# Altium schematic sheet BACKPMAN30_CPU.SchDoc
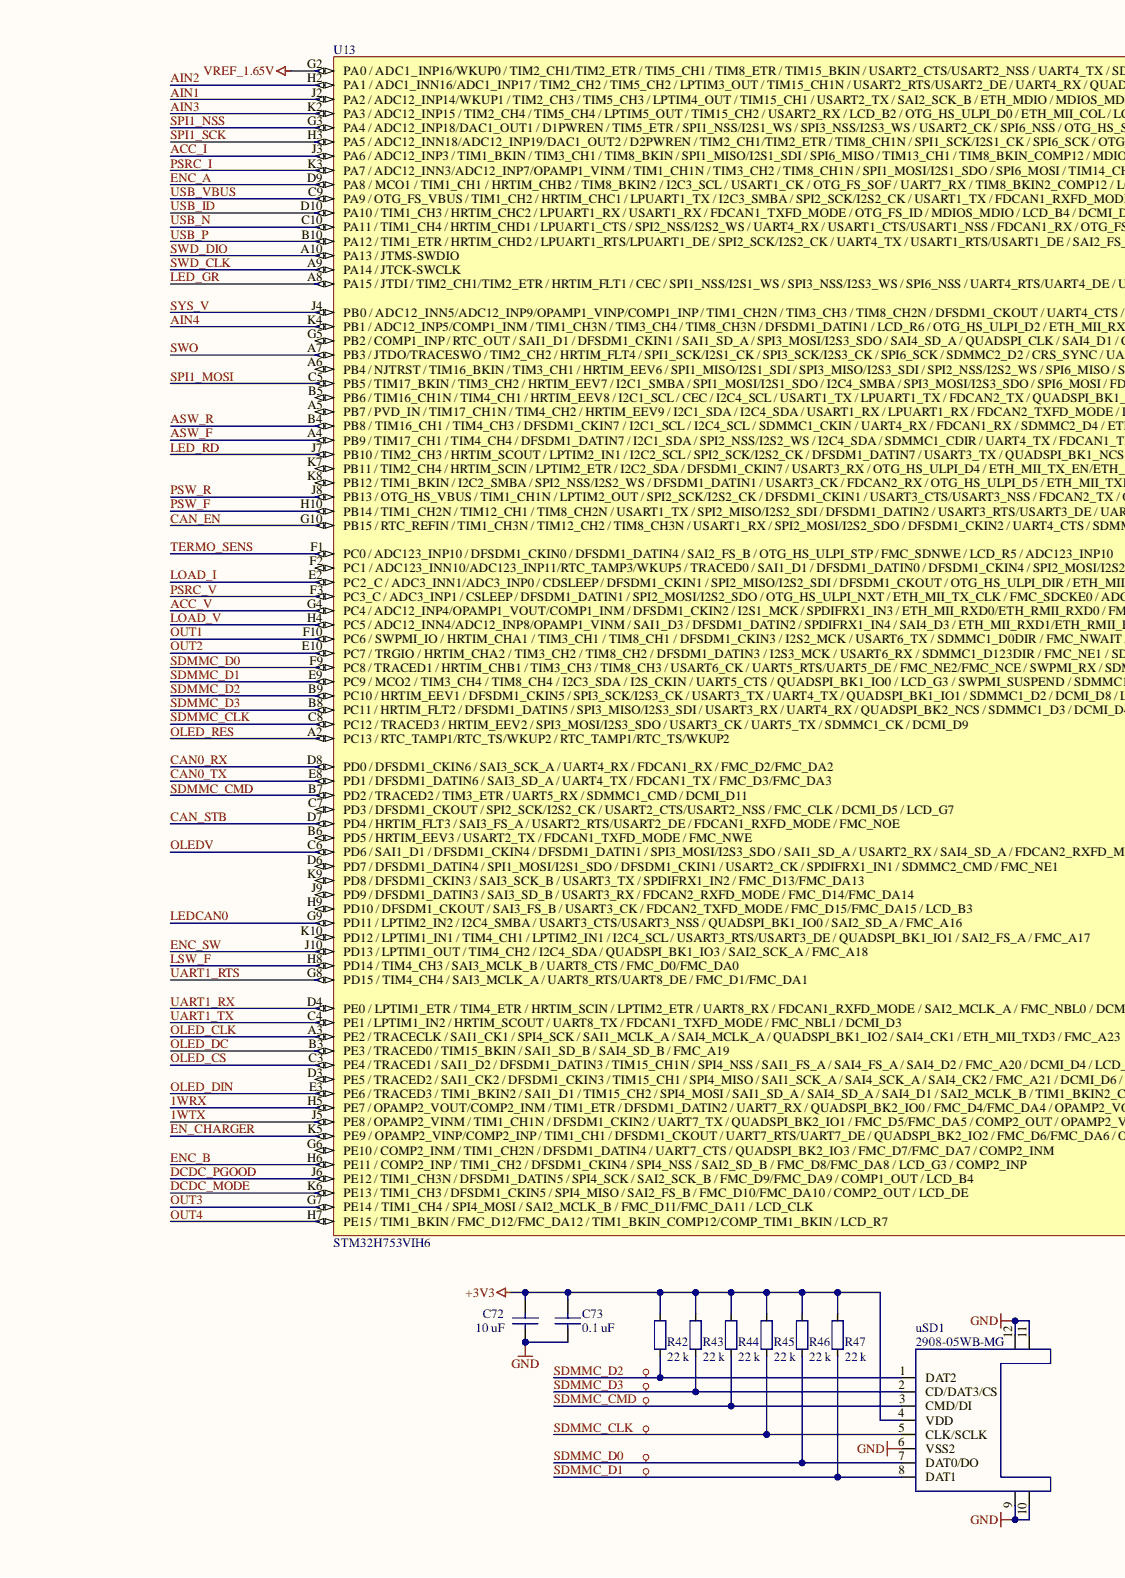
[diagram p0: BACKPMAN30_CPU.SchDoc, left]
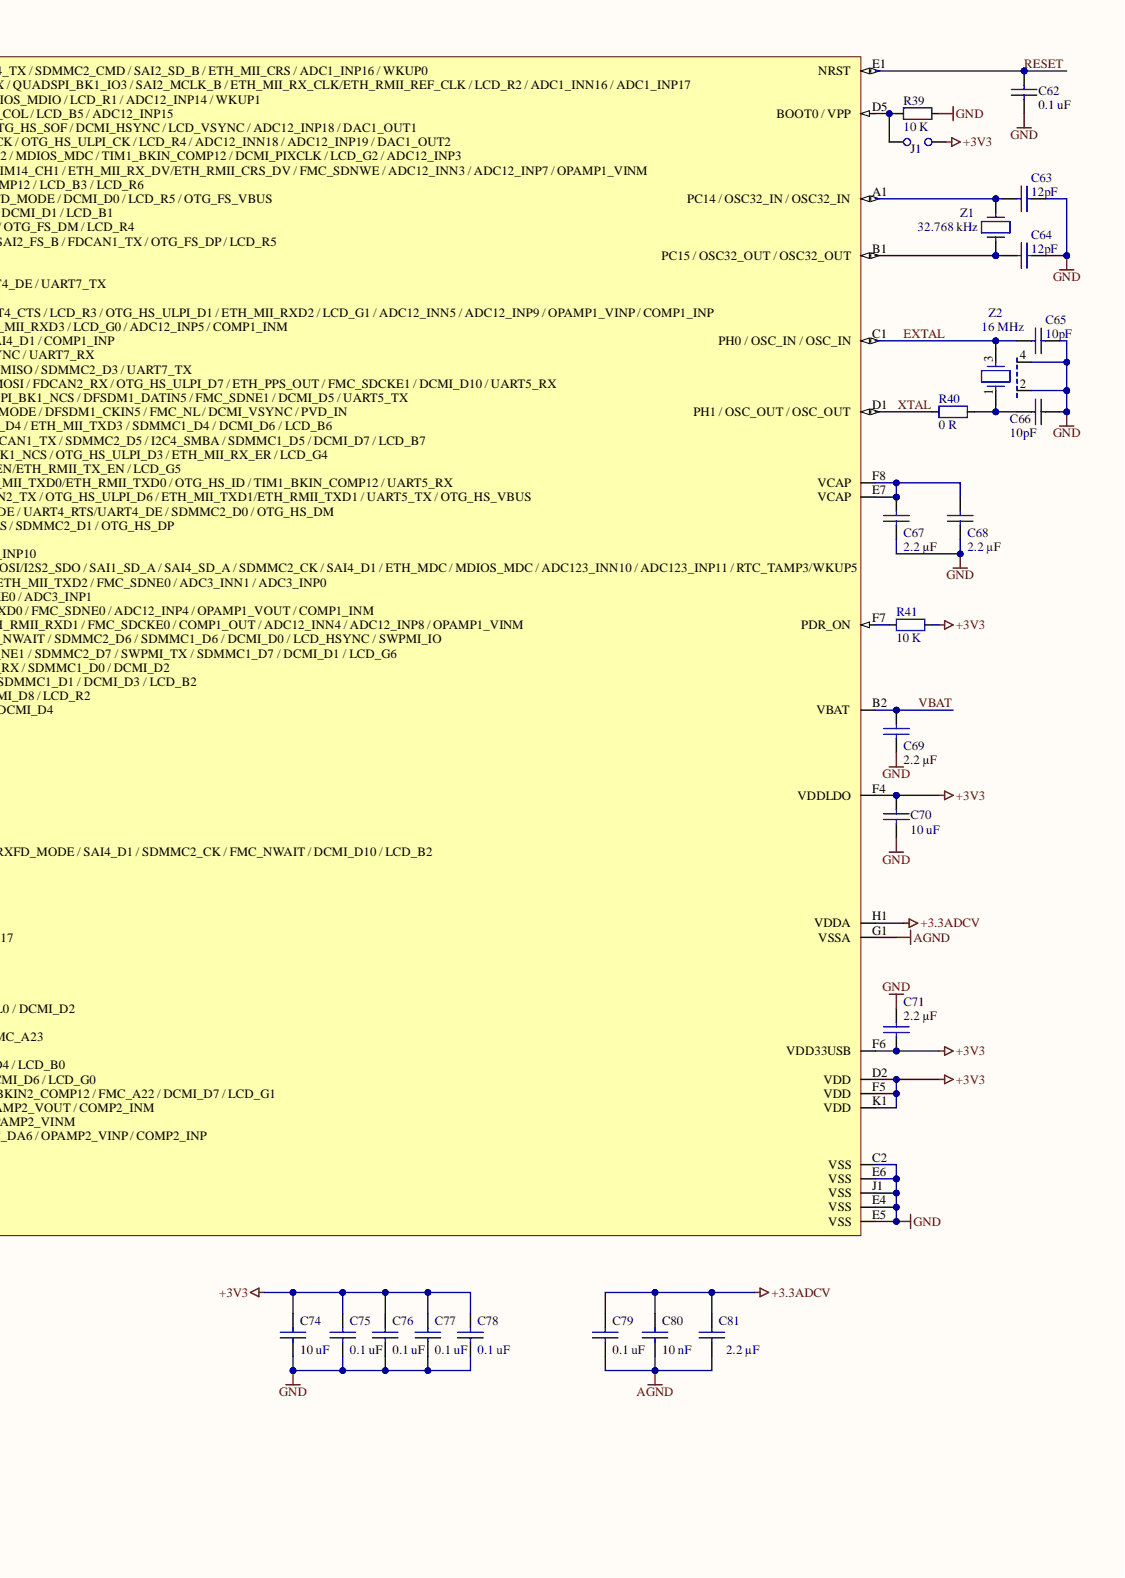
[diagram p1: BACKPMAN30_CPU.SchDoc, right]

## Parts
C62  value 0.1 uF  [1608[0603]]  (hidden comment: CL10B104KA8NNND)
C63  value 12pF  [0402 CAP]  (hidden comment: Capacitor)
C64  value 12pF  [0402 CAP]  (hidden comment: Capacitor)
C65  value 10pF  [0402 CAP]  (hidden comment: Capacitor)
C66  value 10pF  [0402 CAP]  (hidden comment: Capacitor)
C67  value 2.2 µF  [1608[0603]]  (hidden comment: C1608X5R1C225K080AB)
C68  value 2.2 µF  [1608[0603]]  (hidden comment: C1608X5R1C225K080AB)
C69  value 2.2 µF  [1608[0603]]  (hidden comment: C1608X5R1C225K080AB)
C70  value 10 uF  [2012[0805]]  (hidden comment: GRM21BR61E106KA73L)
C71  value 2.2 µF  [1608[0603]]  (hidden comment: C1608X5R1C225K080AB)
C72  value 10 uF  [2012[0805]]  (hidden comment: GRM21BR61E106KA73L)
C73  value 0.1 uF  [1608[0603]]  (hidden comment: 0603B104K500CT)
C74  value 10 uF  [2012[0805]]  (hidden comment: GRM21BR61E106KA73L)
C75  value 0.1 uF  [1608[0603]]  (hidden comment: CL10B104KA8NNND)
C76  value 0.1 uF  [1608[0603]]  (hidden comment: CL10B104KA8NNND)
C77  value 0.1 uF  [1608[0603]]  (hidden comment: CL10B104KA8NNND)
C78  value 0.1 uF  [1608[0603]]  (hidden comment: CL10B104KA8NNND)
C79  value 0.1 uF  [1608[0603]]  (hidden comment: CL10B104KA8NNND)
C80  value 10 nF  [1608[0603]]  (hidden comment: GMC10X7R103M50NT)
C81  value 2.2 µF  [1608[0603]]  (hidden comment: C1608X5R1C225K080AB)
J1  PH1-02-TA-HT  [HDR1X2V]  (value not drawn)
R39  value 10 K  [0603]  (hidden comment: RMCF0603FT10K0)
R40  value 0 R  [0603]  (hidden comment: CR0603-10W-000T)
R41  value 10 K  [0603]  (hidden comment: RMCF0603FT10K0)
R42  value 22 k  [0402]  (hidden comment: Resistor)
R43  value 22 k  [0402]  (hidden comment: Resistor)
R44  value 22 k  [0402]  (hidden comment: Resistor)
R45  value 22 k  [0402]  (hidden comment: Resistor)
R46  value 22 k  [0402]  (hidden comment: Resistor)
R47  value 22 k  [0402]  (hidden comment: Resistor)
U13  STM32H753VIH6  [TFBGA100]
uSD1  2908-05WB-MG  [2908-05WB-MG]
Z1  value 32.768 kHz  [ABS7]  (hidden comment: ABS07-32.768KHZ-T)
Z2  value 16 MHz  [4-SMD 2.00mm x 1.60mm]  (hidden comment: ABM11-16.000MHZ-9-B1U-T)

## Nets
+3.3ADCV: C79.2 C80.1 C81.1 U13.H1(VDDA)
+3V3: C70.2 C71.2 C72.2 C73.2 C74.2 C75.2 C76.2 C77.2 C78.2 J1.2 R41.1 R42.2 R43.2 R44.2 R45.2 R46.2 R47.2 U13.D2(VDD) U13.F4(VDDLDO) U13.F5(VDD) U13.F6(VDD33USB) U13.K1(VDD) uSD1.4(VDD)
AGND: C79.1 C80.2 C81.2 U13.G1(VSSA)
GND: C62.2 C63.2 C64.2 C65.2 C66.2 C67.2 C68.2 C69.2 C70.1 C71.1 C72.1 C73.1 C74.1 C75.1 C76.1 C77.1 C78.1 R39.1 U13.C2(VSS) U13.E4(VSS) U13.E5(VSS) U13.E6(VSS) U13.J1(VSS) uSD1.6(VSS2) uSD1.9 uSD1.10 uSD1.11 uSD1.12 Z2.2 Z2.4
VREF_1.65V: U13.G2
1WRX: U13.H5
1WTX: U13.J5(PE8_/_OPAMP2_VINM_/_TIM1_CH1N_/_DFSDM1_CKIN2_/_UART7_TX_/_QUADSPI_BK2_IO1_/_FMC_D5/FMC_DA5_/_COMP2_OUT_/_OPAMP2_VINM)
ACC_I: U13.J3(PA6_/_ADC12_INP3_/_TIM1_BKIN_/_TIM3_CH1_/_TIM8_BKIN_/_SPI1_MISO/I2S1_SDI_/_SPI6_MISO_/_TIM13_CH1_/_TIM8_BKIN_COMP12_/_MDIOS_MDC_/_TIM1_BKIN_COMP12_/_DCMI_PIXCLK_/_LCD_G2_/_ADC12_INP3)
ACC_V: U13.G4(PC4_/_ADC12_INP4/OPAMP1_VOUT/COMP1_INM_/_DFSDM1_CKIN2_/_I2S1_MCK_/_SPDIFRX1_IN3_/_ETH_MII_RXD0/ETH_RMII_RXD0_/_FMC_SDNE0_/_ADC12_INP4_/_OPAMP1_VOUT_/_COMP1_INM)
AIN1: U13.J2(PA2_/_ADC12_INP14/WKUP1_/_TIM2_CH3_/_TIM5_CH3_/_LPTIM4_OUT_/_TIM15_CH1_/_USART2_TX_/_SAI2_SCK_B_/_ETH_MDIO_/_MDIOS_MDIO_/_LCD_R1_/_ADC12_INP14_/_WKUP1)
AIN2: U13.H2(PA1_/_ADC1_INN16/ADC1_INP17_/_TIM2_CH2_/_TIM5_CH2_/_LPTIM3_OUT_/_TIM15_CH1N_/_USART2_RTS/USART2_DE_/_UART4_RX_/_QUADSPI_BK1_IO3_/_SAI2_MCLK_B_/_ETH_MII_RX_CLK/ETH_RMII_REF_CLK_/_LCD_R2_/_ADC1_INN16_/_ADC1_INP17)
AIN3: U13.K2
AIN4: U13.K4(PB1_/_ADC12_INP5/COMP1_INM_/_TIM1_CH3N_/_TIM3_CH4_/_TIM8_CH3N_/_DFSDM1_DATIN1_/_LCD_R6_/_OTG_HS_ULPI_D2_/_ETH_MII_RXD3_/_LCD_G0_/_ADC12_INP5_/_COMP1_INM)
ASW_F: U13.A4(PB9_/_TIM17_CH1_/_TIM4_CH4_/_DFSDM1_DATIN7_/_I2C1_SDA_/_SPI2_NSS/I2S2_WS_/_I2C4_SDA_/_SDMMC1_CDIR_/_UART4_TX_/_FDCAN1_TX_/_SDMMC2_D5_/_I2C4_SMBA_/_SDMMC1_D5_/_DCMI_D7_/_LCD_B7)
ASW_R: U13.B4(PB8_/_TIM16_CH1_/_TIM4_CH3_/_DFSDM1_CKIN7_/_I2C1_SCL_/_I2C4_SCL_/_SDMMC1_CKIN_/_UART4_RX_/_FDCAN1_RX_/_SDMMC2_D4_/_ETH_MII_TXD3_/_SDMMC1_D4_/_DCMI_D6_/_LCD_B6)
CAN0_RX: U13.D8(PD0_/_DFSDM1_CKIN6_/_SAI3_SCK_A_/_UART4_RX_/_FDCAN1_RX_/_FMC_D2/FMC_DA2)
CAN0_TX: U13.E8(PD1_/_DFSDM1_DATIN6_/_SAI3_SD_A_/_UART4_TX_/_FDCAN1_TX_/_FMC_D3/FMC_DA3)
CAN_EN: U13.G10(PB15_/_RTC_REFIN_/_TIM1_CH3N_/_TIM12_CH2_/_TIM8_CH3N_/_USART1_RX_/_SPI2_MOSI/I2S2_SDO_/_DFSDM1_CKIN2_/_UART4_CTS_/_SDMMC2_D1_/_OTG_HS_DP)
CAN_STB: U13.D7(PD4_/_HRTIM_FLT3_/_SAI3_FS_A_/_USART2_RTS/USART2_DE_/_FDCAN1_RXFD_MODE_/_FMC_NOE)
DCDC_MODE: U13.K6(PE13_/_TIM1_CH3_/_DFSDM1_CKIN5_/_SPI4_MISO_/_SAI2_FS_B_/_FMC_D10/FMC_DA10_/_COMP2_OUT_/_LCD_DE)
DCDC_PGOOD: U13.J6(PE12_/_TIM1_CH3N_/_DFSDM1_DATIN5_/_SPI4_SCK_/_SAI2_SCK_B_/_FMC_D9/FMC_DA9_/_COMP1_OUT_/_LCD_B4)
EN_CHARGER: U13.K5(PE9_/_OPAMP2_VINP/COMP2_INP_/_TIM1_CH1_/_DFSDM1_CKOUT_/_UART7_RTS/UART7_DE_/_QUADSPI_BK2_IO2_/_FMC_D6/FMC_DA6_/_OPAMP2_VINP_/_COMP2_INP)
ENC_A: U13.D9(PA8_/_MCO1_/_TIM1_CH1_/_HRTIM_CHB2_/_TIM8_BKIN2_/_I2C3_SCL_/_USART1_CK_/_OTG_FS_SOF_/_UART7_RX_/_TIM8_BKIN2_COMP12_/_LCD_B3_/_LCD_R6)
ENC_B: U13.H6(PE11_/_COMP2_INP_/_TIM1_CH2_/_DFSDM1_CKIN4_/_SPI4_NSS_/_SAI2_SD_B_/_FMC_D8/FMC_DA8_/_LCD_G3_/_COMP2_INP)
ENC_SW: U13.J10(PD13_/_LPTIM1_OUT_/_TIM4_CH2_/_I2C4_SDA_/_QUADSPI_BK1_IO3_/_SAI2_SCK_A_/_FMC_A18)
EXTAL: C65.1 U13.C1(PH0_/_OSC_IN_/_OSC_IN) Z2.3
LED_GR: U13.A8(PA15_/_JTDI_/_TIM2_CH1/TIM2_ETR_/_HRTIM_FLT1_/_CEC_/_SPI1_NSS/I2S1_WS_/_SPI3_NSS/I2S3_WS_/_SPI6_NSS_/_UART4_RTS/UART4_DE_/_UART7_TX)
LED_RD: U13.J7(PB10_/_TIM2_CH3_/_HRTIM_SCOUT_/_LPTIM2_IN1_/_I2C2_SCL_/_SPI2_SCK/I2S2_CK_/_DFSDM1_DATIN7_/_USART3_TX_/_QUADSPI_BK1_NCS_/_OTG_HS_ULPI_D3_/_ETH_MII_RX_ER_/_LCD_G4)
LEDCAN0: U13.G9(PD11_/_LPTIM2_IN2_/_I2C4_SMBA_/_USART3_CTS/USART3_NSS_/_QUADSPI_BK1_IO0_/_SAI2_SD_A_/_FMC_A16)
LOAD_I: U13.E2(PC2_C_/_ADC3_INN1/ADC3_INP0_/_CDSLEEP_/_DFSDM1_CKIN1_/_SPI2_MISO/I2S2_SDI_/_DFSDM1_CKOUT_/_OTG_HS_ULPI_DIR_/_ETH_MII_TXD2_/_FMC_SDNE0_/_ADC3_INN1_/_ADC3_INP0)
LOAD_V: U13.H4
LSW_F: U13.H8(PD14_/_TIM4_CH3_/_SAI3_MCLK_B_/_UART8_CTS_/_FMC_D0/FMC_DA0)
OLED_CLK: U13.A3(PE2_/_TRACECLK_/_SAI1_CK1_/_SPI4_SCK_/_SAI1_MCLK_A_/_SAI4_MCLK_A_/_QUADSPI_BK1_IO2_/_SAI4_CK1_/_ETH_MII_TXD3_/_FMC_A23)
OLED_CS: U13.C3(PE4_/_TRACED1_/_SAI1_D2_/_DFSDM1_DATIN3_/_TIM15_CH1N_/_SPI4_NSS_/_SAI1_FS_A_/_SAI4_FS_A_/_SAI4_D2_/_FMC_A20_/_DCMI_D4_/_LCD_B0)
OLED_DC: U13.B3(PE3_/_TRACED0_/_TIM15_BKIN_/_SAI1_SD_B_/_SAI4_SD_B_/_FMC_A19)
OLED_DIN: U13.E3
OLED_RES: U13.A2(PC13_/_RTC_TAMP1/RTC_TS/WKUP2_/_RTC_TAMP1/RTC_TS/WKUP2)
OLEDV: U13.C6(PD6_/_SAI1_D1_/_DFSDM1_CKIN4_/_DFSDM1_DATIN1_/_SPI3_MOSI/I2S3_SDO_/_SAI1_SD_A_/_USART2_RX_/_SAI4_SD_A_/_FDCAN2_RXFD_MODE_/_SAI4_D1_/_SDMMC2_CK_/_FMC_NWAIT_/_DCMI_D10_/_LCD_B2)
OUT1: U13.F10(PC6_/_SWPMI_IO_/_HRTIM_CHA1_/_TIM3_CH1_/_TIM8_CH1_/_DFSDM1_CKIN3_/_I2S2_MCK_/_USART6_TX_/_SDMMC1_D0DIR_/_FMC_NWAIT_/_SDMMC2_D6_/_SDMMC1_D6_/_DCMI_D0_/_LCD_HSYNC_/_SWPMI_IO)
OUT2: U13.E10(PC7_/_TRGIO_/_HRTIM_CHA2_/_TIM3_CH2_/_TIM8_CH2_/_DFSDM1_DATIN3_/_I2S3_MCK_/_USART6_RX_/_SDMMC1_D123DIR_/_FMC_NE1_/_SDMMC2_D7_/_SWPMI_TX_/_SDMMC1_D7_/_DCMI_D1_/_LCD_G6)
OUT3: U13.G7(PE14_/_TIM1_CH4_/_SPI4_MOSI_/_SAI2_MCLK_B_/_FMC_D11/FMC_DA11_/_LCD_CLK)
OUT4: U13.H7(PE15_/_TIM1_BKIN_/_FMC_D12/FMC_DA12_/_TIM1_BKIN_COMP12/COMP_TIM1_BKIN_/_LCD_R7)
PSRC_I: U13.K3(PA7_/_ADC12_INN3/ADC12_INP7/OPAMP1_VINM_/_TIM1_CH1N_/_TIM3_CH2_/_TIM8_CH1N_/_SPI1_MOSI/I2S1_SDO_/_SPI6_MOSI_/_TIM14_CH1_/_ETH_MII_RX_DV/ETH_RMII_CRS_DV_/_FMC_SDNWE_/_ADC12_INN3_/_ADC12_INP7_/_OPAMP1_VINM)
PSRC_V: U13.F3(PC3_C_/_ADC3_INP1_/_CSLEEP_/_DFSDM1_DATIN1_/_SPI2_MOSI/I2S2_SDO_/_OTG_HS_ULPI_NXT_/_ETH_MII_TX_CLK_/_FMC_SDCKE0_/_ADC3_INP1)
PSW_F: U13.H10(PB14_/_TIM1_CH2N_/_TIM12_CH1_/_TIM8_CH2N_/_USART1_TX_/_SPI2_MISO/I2S2_SDI_/_DFSDM1_DATIN2_/_USART3_RTS/USART3_DE_/_UART4_RTS/UART4_DE_/_SDMMC2_D0_/_OTG_HS_DM)
PSW_R: U13.J8
RESET: C62.1 U13.E1(NRST)
SDMMC_CLK: R45.1 U13.C8(PC12_/_TRACED3_/_HRTIM_EEV2_/_SPI3_MOSI/I2S3_SDO_/_USART3_CK_/_UART5_TX_/_SDMMC1_CK_/_DCMI_D9) uSD1.5(CLK/SCLK)
SDMMC_CMD: R44.1 U13.B7(PD2_/_TRACED2_/_TIM3_ETR_/_UART5_RX_/_SDMMC1_CMD_/_DCMI_D11) uSD1.3(CMD/DI)
SDMMC_D0: R46.1 U13.F9(PC8_/_TRACED1_/_HRTIM_CHB1_/_TIM3_CH3_/_TIM8_CH3_/_USART6_CK_/_UART5_RTS/UART5_DE_/_FMC_NE2/FMC_NCE_/_SWPMI_RX_/_SDMMC1_D0_/_DCMI_D2) uSD1.7(DAT0/DO)
SDMMC_D1: R47.1 U13.E9(PC9_/_MCO2_/_TIM3_CH4_/_TIM8_CH4_/_I2C3_SDA_/_I2S_CKIN_/_UART5_CTS_/_QUADSPI_BK1_IO0_/_LCD_G3_/_SWPMI_SUSPEND_/_SDMMC1_D1_/_DCMI_D3_/_LCD_B2) uSD1.8(DAT1)
SDMMC_D2: R42.1 U13.B9(PC10_/_HRTIM_EEV1_/_DFSDM1_CKIN5_/_SPI3_SCK/I2S3_CK_/_USART3_TX_/_UART4_TX_/_QUADSPI_BK1_IO1_/_SDMMC1_D2_/_DCMI_D8_/_LCD_R2) uSD1.1(DAT2)
SDMMC_D3: R43.1 U13.B8(PC11_/_HRTIM_FLT2_/_DFSDM1_DATIN5_/_SPI3_MISO/I2S3_SDI_/_USART3_RX_/_UART4_RX_/_QUADSPI_BK2_NCS_/_SDMMC1_D3_/_DCMI_D4) uSD1.2(CD/DAT3/CS)
SPI1_MOSI: U13.C5(PB5_/_TIM17_BKIN_/_TIM3_CH2_/_HRTIM_EEV7_/_I2C1_SMBA_/_SPI1_MOSI/I2S1_SDO_/_I2C4_SMBA_/_SPI3_MOSI/I2S3_SDO_/_SPI6_MOSI_/_FDCAN2_RX_/_OTG_HS_ULPI_D7_/_ETH_PPS_OUT_/_FMC_SDCKE1_/_DCMI_D10_/_UART5_RX)
SPI1_NSS: U13.G3(PA4_/_ADC12_INP18/DAC1_OUT1_/_D1PWREN_/_TIM5_ETR_/_SPI1_NSS/I2S1_WS_/_SPI3_NSS/I2S3_WS_/_USART2_CK_/_SPI6_NSS_/_OTG_HS_SOF_/_DCMI_HSYNC_/_LCD_VSYNC_/_ADC12_INP18_/_DAC1_OUT1)
SPI1_SCK: U13.H3(PA5_/_ADC12_INN18/ADC12_INP19/DAC1_OUT2_/_D2PWREN_/_TIM2_CH1/TIM2_ETR_/_TIM8_CH1N_/_SPI1_SCK/I2S1_CK_/_SPI6_SCK_/_OTG_HS_ULPI_CK_/_LCD_R4_/_ADC12_INN18_/_ADC12_INP19_/_DAC1_OUT2)
SWD_CLK: U13.A9(PA14_/_JTCK-SWCLK)
SWD_DIO: U13.A10(PA13_/_JTMS-SWDIO)
SWO: U13.A7(PB3_/_JTDO/TRACESWO_/_TIM2_CH2_/_HRTIM_FLT4_/_SPI1_SCK/I2S1_CK_/_SPI3_SCK/I2S3_CK_/_SPI6_SCK_/_SDMMC2_D2_/_CRS_SYNC_/_UART7_RX)
SYS_V: U13.J4(PB0_/_ADC12_INN5/ADC12_INP9/OPAMP1_VINP/COMP1_INP_/_TIM1_CH2N_/_TIM3_CH3_/_TIM8_CH2N_/_DFSDM1_CKOUT_/_UART4_CTS_/_LCD_R3_/_OTG_HS_ULPI_D1_/_ETH_MII_RXD2_/_LCD_G1_/_ADC12_INN5_/_ADC12_INP9_/_OPAMP1_VINP_/_COMP1_INP)
TERMO_SENS: U13.F1(PC0_/_ADC123_INP10_/_DFSDM1_CKIN0_/_DFSDM1_DATIN4_/_SAI2_FS_B_/_OTG_HS_ULPI_STP_/_FMC_SDNWE_/_LCD_R5_/_ADC123_INP10)
UART1_RTS: U13.G8(PD15_/_TIM4_CH4_/_SAI3_MCLK_A_/_UART8_RTS/UART8_DE_/_FMC_D1/FMC_DA1)
UART1_RX: U13.D4(PE0_/_LPTIM1_ETR_/_TIM4_ETR_/_HRTIM_SCIN_/_LPTIM2_ETR_/_UART8_RX_/_FDCAN1_RXFD_MODE_/_SAI2_MCLK_A_/_FMC_NBL0_/_DCMI_D2)
UART1_TX: U13.C4(PE1_/_LPTIM1_IN2_/_HRTIM_SCOUT_/_UART8_TX_/_FDCAN1_TXFD_MODE_/_FMC_NBL1_/_DCMI_D3)
USB_ID: U13.D10(PA10_/_TIM1_CH3_/_HRTIM_CHC2_/_LPUART1_RX_/_USART1_RX_/_FDCAN1_TXFD_MODE_/_OTG_FS_ID_/_MDIOS_MDIO_/_LCD_B4_/_DCMI_D1_/_LCD_B1)
USB_N: U13.C10(PA11_/_TIM1_CH4_/_HRTIM_CHD1_/_LPUART1_CTS_/_SPI2_NSS/I2S2_WS_/_UART4_RX_/_USART1_CTS/USART1_NSS_/_FDCAN1_RX_/_OTG_FS_DM_/_LCD_R4)
USB_P: U13.B10(PA12_/_TIM1_ETR_/_HRTIM_CHD2_/_LPUART1_RTS/LPUART1_DE_/_SPI2_SCK/I2S2_CK_/_UART4_TX_/_USART1_RTS/USART1_DE_/_SAI2_FS_B_/_FDCAN1_TX_/_OTG_FS_DP_/_LCD_R5)
USB_VBUS: U13.C9
VBAT: C69.1 U13.B2(VBAT)
XTAL: R40.2 U13.D1(PH1_/_OSC_OUT_/_OSC_OUT)
NetC63_1: C63.1 U13.A1(PC14_/_OSC32_IN_/_OSC32_IN) Z1.2
NetC64_1: C64.1 U13.B1(PC15_/_OSC32_OUT_/_OSC32_OUT) Z1.1
NetC66_1: C66.1 R40.1 Z2.1
NetC67_1: C67.1 C68.1 U13.E7(VCAP) U13.F8(VCAP)
NetJ1_1: J1.1 R39.2 U13.D5(BOOT0_/_VPP)
NetR41_2: R41.2 U13.F7(PDR_ON)

Not connected: U13 A5 A6(PB4_/_NJTRST_/_TIM16_BKIN_/_TIM3_CH1_/_HRTIM_EEV6_/_SPI1_MISO/I2S1_SDI_/_SPI3_MISO/I2S3_SDI_/_SPI2_NSS/I2S2_WS_/_SPI6_MISO_/_SDMMC2_D3_/_UART7_TX) B5(PB6_/_TIM16_CH1N_/_TIM4_CH1_/_HRTIM_EEV8_/_I2C1_SCL_/_CEC_/_I2C4_SCL_/_USART1_TX_/_LPUART1_TX_/_FDCAN2_TX_/_QUADSPI_BK1_NCS_/_DFSDM1_DATIN5_/_FMC_SDNE1_/_DCMI_D5_/_UART5_TX) B6(PD5_/_HRTIM_EEV3_/_USART2_TX_/_FDCAN1_TXFD_MODE_/_FMC_NWE) C7(PD3_/_DFSDM1_CKOUT_/_SPI2_SCK/I2S2_CK_/_USART2_CTS/USART2_NSS_/_FMC_CLK_/_DCMI_D5_/_LCD_G7) D3 D6(PD7_/_DFSDM1_DATIN4_/_SPI1_MOSI/I2S1_SDO_/_DFSDM1_CKIN1_/_USART2_CK_/_SPDIFRX1_IN1_/_SDMMC2_CMD_/_FMC_NE1) F2(PC1_/_ADC123_INN10/ADC123_INP11/RTC_TAMP3/WKUP5_/_TRACED0_/_SAI1_D1_/_DFSDM1_DATIN0_/_DFSDM1_CKIN4_/_SPI2_MOSI/I2S2_SDO_/_SAI1_SD_A_/_SAI4_SD_A_/_SDMMC2_CK_/_SAI4_D1_/_ETH_MDC_/_MDIOS_MDC_/_ADC123_INN10_/_ADC123_INP11_/_RTC_TAMP3/WKUP5) G5 G6(PE10_/_COMP2_INM_/_TIM1_CH2N_/_DFSDM1_DATIN4_/_UART7_CTS_/_QUADSPI_BK2_IO3_/_FMC_D7/FMC_DA7_/_COMP2_INM) H9(PD10_/_DFSDM1_CKOUT_/_SAI3_FS_B_/_USART3_CK_/_FDCAN2_TXFD_MODE_/_FMC_D15/FMC_DA15_/_LCD_B3) J9(PD9_/_DFSDM1_DATIN3_/_SAI3_SD_B_/_USART3_RX_/_FDCAN2_RXFD_MODE_/_FMC_D14/FMC_DA14) K7(PB11_/_TIM2_CH4_/_HRTIM_SCIN_/_LPTIM2_ETR_/_I2C2_SDA_/_DFSDM1_CKIN7_/_USART3_RX_/_OTG_HS_ULPI_D4_/_ETH_MII_TX_EN/ETH_RMII_TX_EN_/_LCD_G5) K8 K9(PD8_/_DFSDM1_CKIN3_/_SAI3_SCK_B_/_USART3_TX_/_SPDIFRX1_IN2_/_FMC_D13/FMC_DA13) K10(PD12_/_LPTIM1_IN1_/_TIM4_CH1_/_LPTIM2_IN1_/_I2C4_SCL_/_USART3_RTS/USART3_DE_/_QUADSPI_BK1_IO1_/_SAI2_FS_A_/_FMC_A17)

## Library data of the parts
C62 C73 C75-C78 = 0603B104K500CT: 0.1 µF ±10% 50V Ceramic Capacitor X7R 0603 (1608 Metric), -55°C ~ 125°C; Manufacturer: Walsin Technology Corporation; PartNum: 1292-1643-1-ND; Tolerance: +-10%; Component Kind: Standard; Distributor: Digi-Key; PartName: 0603B104K500CT; Price: 0.0062 Euro; Voltage: 50V; Library Name: ea_Capacitors_0603.SCHLIB
C63 C64 = 0402N120G500CT: 12pF, ±2%, 50V, C0G, NP0, 0402 (1005 Metric), -55°C ~ 125°C, -, General Purpose; Manufacturer: Walsin Technology Corporation; PartNum: 1292-1250-1-ND; Database Table Name: Cap_0402_50V; Component Kind: Standard; Distributor: Digi-Key; Features: -; Operating Temperature: -55°C ~ 125°C; Package / Case: 0402 (1005 Metric); Part Number: 0402N120G500CT; PartName: 0402N120G500CT; Price: 0.10000; Temperature Coefficient: C0G, NP0; Tolerance: ±2%; Voltage - Rated: 50V; Library Name: ea_PassivComps.DbLib
C65 C66 = GCM1555C1H100FA16D: 10pF, ±1%, 50V, C0G, NP0, 0402 (1005 Metric), -55°C ~ 125°C, -, Automotive; Manufacturer: Murata Electronics North America; PartNum: 490-14348-1-ND; Database Table Name: Cap_0402_50V; Component Kind: Standard; Distributor: Digi-Key; Features: -; Operating Temperature: -55°C ~ 125°C; Package / Case: 0402 (1005 Metric); Part Number: GCM1555C1H100FA16D; PartName: GCM1555C1H100FA16D; Price: 0.15000; Temperature Coefficient: C0G, NP0; Tolerance: ±1%; Voltage - Rated: 50V; Library Name: ea_PassivComps.DbLib
C67-C69 C71 C81 = C1608X5R1C225K080AB: 2.2 µF ±10% 16V Ceramic Capacitor X5R 0603 (1608 Metric), -55°C ~ 85°C; Manufacturer: TDK Corporation; PartNum: 445-5157-1-ND; Component Kind: Standard; Distributor: Digi-Key; PartName: C1608X5R1C225K080AB; Price: 0.04$; Tolerance: ±10%; Voltage: 16 V; Library Name: ea_Capacitors_0603.SCHLIB
C70 C72 = GRM21BR61E106KA73L: 10 µF ±10%, 25V, Ceramic Capacitor X5R 0805 (2012 Metric),  -55°C ~ 85°C; Manufacturer: Murata Electronics; PartNum: 490-5523-1-ND; Component Kind: Standard; Distributor: Digi-Key; PartName: GRM21BR61E106KA73L; Price: 0.11$; Tolerance: ±10%; Voltage: 25V; Library Name: ea_Capacitors_0805.SCHLIB
C74 = GRM21BR61E106KA73L: 10 µF ±10%, 25V, Ceramic Capacitor X5R 0805 (2012 Metric),  -55°C ~ 85°C; Manufacturer: Murata Electronics; PartNum: 490-5523-1-ND; Value: 10 uF; Component Kind: Standard; Distributor: Digi-Key; PartName: GRM21BR61E106KA73L; Price: 0.11$; Tolerance: ±10%; Voltage: 25V; Library Name: ea_Capacitors_0805.SCHLIB
C79 = 0603B104K500CT: 0.1 µF ±10% 50V Ceramic Capacitor X7R 0603 (1608 Metric), -55°C ~ 125°C; Manufacturer: Walsin Technology Corporation; PartNum: 1292-1643-1-ND; Tolerance: +-10%; Value: 0.1 uF; Component Kind: Standard; Distributor: Digi-Key; PartName: 0603B104K500CT; Price: 0.0062 Euro; Voltage: 50V; Library Name: ea_Capacitors_0603.SCHLIB
C80 = GMC10X7R103M50NT: 10 nF, ±20%, 50V,  Ceramic Capacitor X7R 0603 (1608 Metric), -55°C ~ 125°C; Manufacturer: CAL-CHIP ELECTRONICS, INC.; PartNum: 2571-GMC10X7R103M50NTTR-ND; Tolerance: +-10%; Component Kind: Standard; Distributor: Digi-Key; PartName: GMC10X7R103M50NT; Price: 0.0047 Euro; Voltage: 50 V; Library Name: ea_Capacitors_0603.SCHLIB
J1 = PH1-02-UA: Connector Header Through Hole 2 position 0.100" (2.54mm), Contact Length - Mating 6 mm; Manufacturer: Adam Tech; PartNum: 2057-PH1-02-UA-ND; Component Kind: Standard; Distributor: Digi-Key; PartName: PH1-02-UA; Library Name: ea_Commutation.SCHLIB
R39 R41 = RMCF0603FT10K0: 10 kOhms ±1%, ±100ppm/°C,  0.1W, 1/10W Chip Resistor 0603 (1608 Metric) Automotive AEC-Q200 Thick Film, -55°C ~ 155°C; Manufacturer: Stackpole Electronics Inc; PartNum: RMCF0603FT10K0CT-ND; Component Kind: Standard; Distributor: Digi-Key; PartName: RMCF0603FT10K0; Price: 0.0017$; Tolerance: 1%; Library Name: ea_Resistors_0603.SchLib
R40 = CR0603-10W-000T: 0 Ohms Jumper 0.1W, 1/10W Chip Resistor 0603 (1608 Metric) - Thick Film, -55°C ~ 155°C; Manufacturer: Venkel; PartNum: 2679-CR0603-10W-000TTR-ND; Voltage: 75 V; Component Kind: Standard; Distributor: Digi-Key; PartName: CR0603-10W-000T; Price: 0.005$; Library Name: ea_Resistors_0603.SchLib
R42-R47 = RMCF0402FT22K0: 22 kOhms, ±1%, 0.063W, 1/16W, Thick Film, ±100ppm/°C, 0402 (1005 Metric), -55°C ~ 155°C, Automotive AEC-Q200; Manufacturer: Stackpole Electronics Inc; PartNum: RMCF0402FT22K0CT-ND; Database Table Name: Res_0402_1%_100ppm; Voltage: 75V; Component Kind: Standard; Distributor: Digi-Key; Features: Automotive AEC-Q200; Operating Temperature: -55°C ~ 155°C; Package / Case: 0402 (1005 Metric); Part Number: RMCF0402FT22K0; PartName: RMCF0402FT22K0; Power (Watts): 0.063W, 1/16W; Price: 0.10000; Temperature Coefficient: ±100ppm/°C; Tolerance: ±1%; Library Name: ea_PassivComps.DbLib
U13 = STM32H753VIH6: Cortex-M7, 480MHz 2MB FLASH, 1MB RAM, 100-TFBGA (8x8); Manufacturer: STMicroelectronics; PartNum: STM32H753VIH6-ND; Component Kind: Standard; Distributor: Digi-Key; PartName: STM32H753VIH6; Price: 9.89$; Library Name: ea_IC_CPU.SCHLIB
uSD1 = 2908-05WB-MG: microSD cadr holder; Manufacturer: 3M; Component Kind: Standard; Distributor: Farnell; PartName: 2908-05WB-MG; PartNum: 2908-05WB-MG; Price: 2.71$; Library Name: ea_CARD_holders.SCHLIB
Z1 = ABS07-32.768KHZ-T: 32.768 kHz, 12.5 pF, 70 kOhm, ±20ppm, 3.2 x 1.5 mm, -40°C ~ 85°C; Manufacturer: Abracon Corporation; PartNum: 535-9542-1-ND; Component Kind: Standard; Distributor: Digi-Key; PartName: ABS07-32.768KHZ-T; Price: 1.09$; Tolerance: 20 ppm; Library Name: ea_OSC_CLK_GEN.SCHLIB
Z2 = ABM11-16.000MHZ-9-B1U-T: 16 MHz, 9 pF, ±10ppm, 2.00mm x 1.60mm, -20°C ~ 70°C; Manufacturer: Abracon LLC; PartNum: 535-13598-1-ND; Value: 16 MHz; Component Kind: Standard; Distributor: Digi-Key; PartName: ABM11-16.000MHZ-9-B1U-T; Price: 0.98 $; Supplier 1: Digi-Key; Supplier Part Number 1: 535-13598-2-ND; Tolerance: 10 ppm; Library Name: ea_OSC_CLK_GEN.SCHLIB
